# Altium schematic sheet Regulator.SchDoc
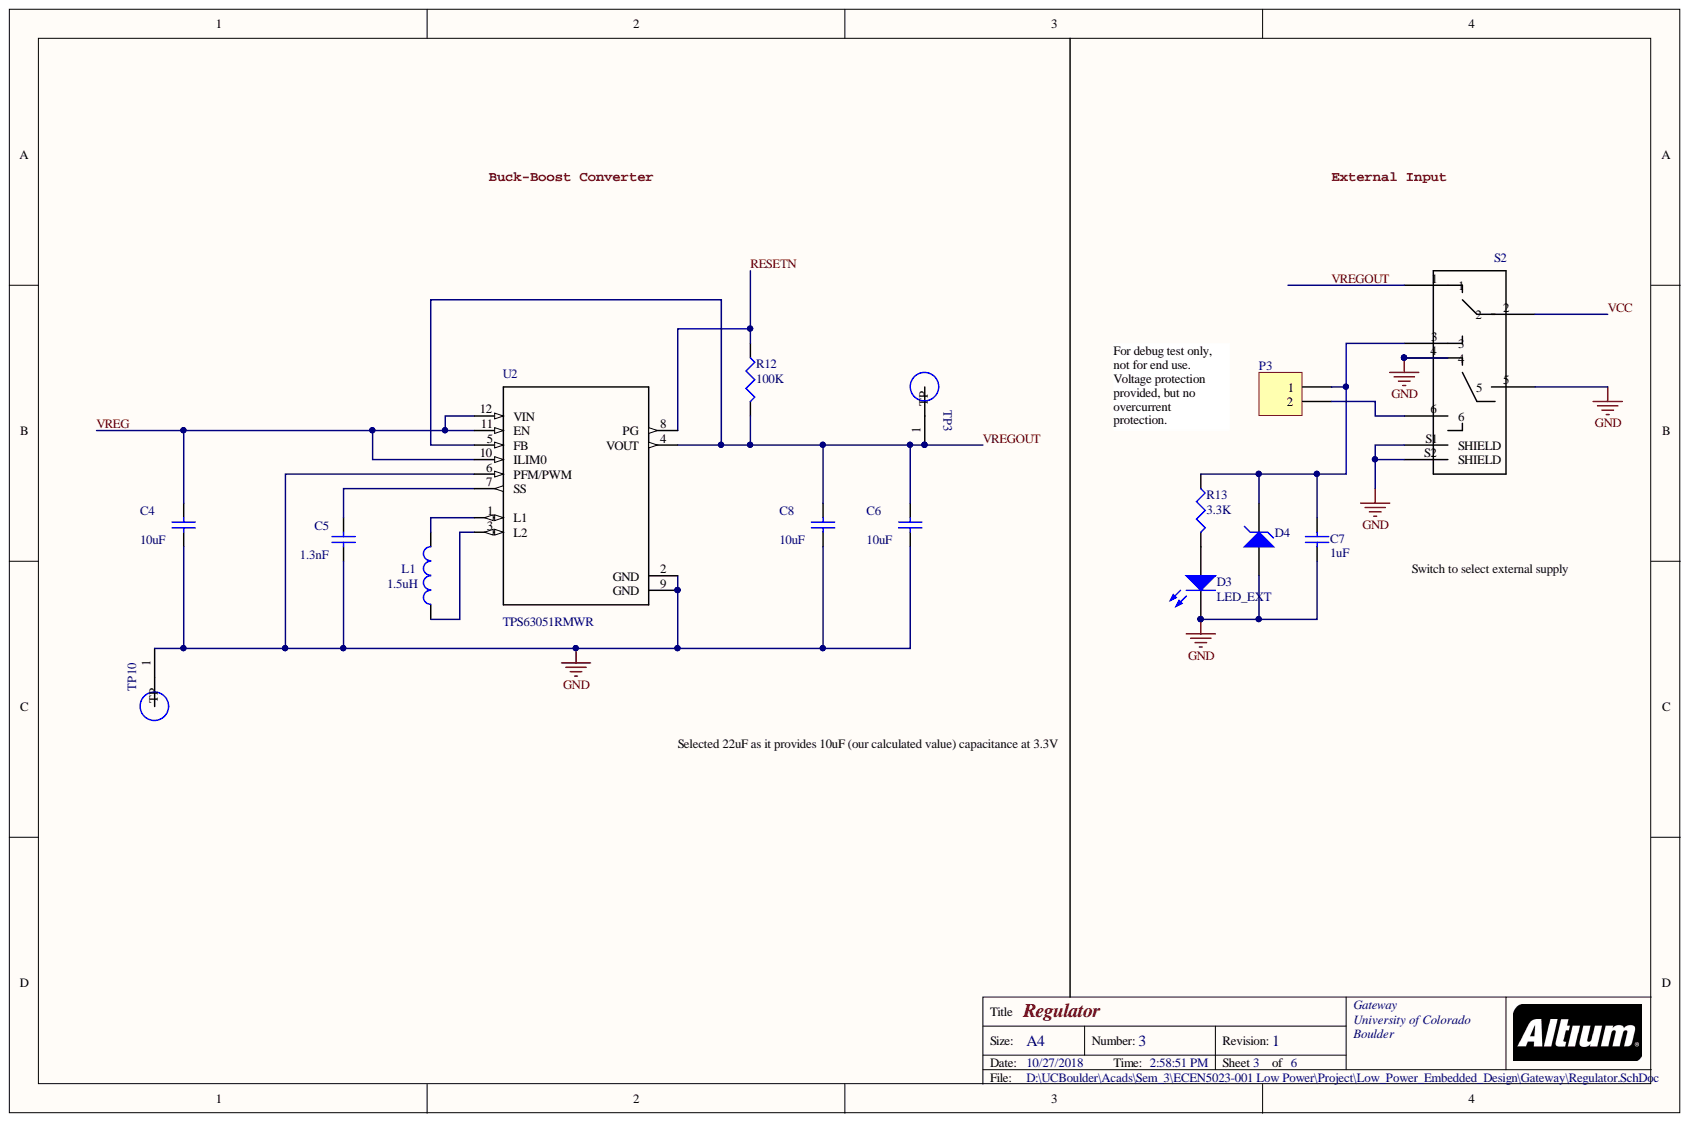
[diagram p0: Regulator.SchDoc]

## Parts
C4  value 10uF  [1608[0603]]  (hidden comment: GRM188R61A106KE69D)
C5  value 1.3nF  [1608[0603]]  (hidden comment: C0603C132J3GAC7867)
C6  value 10uF  [1608[0603]]  (hidden comment: GRM188R61A106KE69D)
C7  value 1uF  [1608[0603]]  (hidden comment: GCM188R71E104KA57D)
C8  value 10uF  [1608[0603]]  (hidden comment: GRM188R61A106KE69D)
D3  LED_EXT  [3.2X1.6X1.1]
D4  TPD1E10B06DPYR  [0402]  (value not drawn)
L1  value 1.5uH  [0603]  (hidden comment: LQM18PN1R5MFHD)
P3  Manufacturer Part Number  [HDR1X2]  (value not drawn)
R12  value 100K  [1608[0603]]  (hidden comment: RG1608P-104-B-T5)
R13  value 3.3K  [1608[0603]]  (hidden comment: ERJ-3GEYJ332V)
S2  1825289-1  [SW_1825289-1_1825289-1(Primary)]  (value not drawn)
TP3  5019  [TP380X203]  (value not drawn)
TP10  5019  [TP380X203]  (value not drawn)
U2  TPS63051RMWR  [QFN50P250X250X100-12N]

## Nets
GND: C4.1 C5.1 C6.1 C7.1 C8.1 D3.2 D4.1 S2.4 S2.5 S2.S1(SHIELD) S2.S2(SHIELD) TP10.1 U2.2(GND) U2.6(PFM/PWM) U2.9(GND)
RESETN: R12.1 U2.8(PG)
VCC: S2.2
VREG: C4.2 U2.10(ILIM0) U2.11(EN) U2.12(VIN)
VREGOUT: C6.2 C8.2 R12.2 S2.1 TP3.1 U2.4(VOUT) U2.5(FB)
NetC5_2: C5.2 U2.7(SS)
NetC7_2: C7.2 D4.2 P3.1 R13.2 S2.3
NetD3_1: D3.1 R13.1
NetL1_1: L1.1 U2.3(L2)
NetL1_2: L1.2 U2.1(L1)
NetP3_2: P3.2 S2.6

## Library data of the parts
C4 C6 C8 = Cap: CAP CER 10UF 10V X5R 0603; Manufacturer: Murata Electronics North America; Component Kind: Standard; ComponentLink1Description: Digi-Key Link; ComponentLink1URL: https://www.digikey.com/product-detail/en/murata-electronics-north-america/GRM188R61A106KE69D/490-10474-1-ND/5026392; Digi-Key Part Number: 490-10474-1-ND; LatestRevisionDate: 17-Jul-2002; LatestRevisionNote: Re-released for DXP Platform.; Library Name: Miscellaneous Devices.IntLib; Manufacturer Part Number: GRM188R61A106KE69D; PackageDescription: Radial Cap, Thru-Hole; 2 Leads; 0.3 in Pin Spacing; PackageReference: RAD-0.3; Published: 8-Jun-2000; Signal Integrity: Cap; Simulation: CAP; Publisher: Altium Limited
C5 = Cap: CAP CER 1300PF 25V C0G/NP0 0603; Manufacturer: KEMET; Component Kind: Standard; ComponentLink1Description: Digi-Key Link; ComponentLink1URL: https://www.digikey.com/product-detail/en/kemet/C0603C132J3GAC7867/399-14953-1-ND/7382502; Digi-Key Part Number: 399-14953-1-ND; LatestRevisionDate: 17-Jul-2002; LatestRevisionNote: Re-released for DXP Platform.; Library Name: Miscellaneous Devices.IntLib; Manufacturer Part Number: C0603C132J3GAC7867; PackageDescription: Radial Cap, Thru-Hole; 2 Leads; 0.3 in Pin Spacing; PackageReference: RAD-0.3; Published: 8-Jun-2000; Signal Integrity: Cap; Simulation: CAP; Publisher: Altium Limited
C7 = Cap Semi: CAP CER 0.1UF 25V X7R 0603; Manufacturer: Murata Electronics North America; Component Kind: Standard; ComponentLink1Description: Digi-Key Link; ComponentLink1URL: https://www.digikey.com/product-detail/en/murata-electronics-north-america/GCM188R71E104KA57D/490-6051-1-ND/3845251; Digi-Key Part Number: 490-6051-1-ND; Library Name: Miscellaneous Devices.IntLib; Manufacturer Part Number: GCM188R71E104KA57D; Signal Integrity: Cap Semi; Simulation: CAP
D3 = LED2: LED GREEN DIFFUSED 0603 SMD; Manufacturer: OSRAM Opto Semiconductors Inc.; Manufacturer Part Number: LG L29K-F2J1-24-Z; Component Kind: Standard; ComponentLink1Description: Digi-Key Link; ComponentLink1URL: https://www.digikey.com/product-detail/en/osram-opto-semiconductors-inc/LG-L29K-F2J1-24-Z/475-3118-1-ND/4899549; Digi-Key Part Number: 475-3118-1-ND; Library Name: Miscellaneous Devices.IntLib; Simulation: LED2
D4 = D Zener: Zener Diode; Manufacturer: Texas Instruments; Component Kind: Standard; ComponentLink1Description: Digi-Key Link; Digi-Key Part Number: 296-30406-1-ND; Library Name: Miscellaneous Devices.IntLib; Manufacturer Part Number: TPD1E10B06DPYR; Signal Integrity: D Zener; Simulation: ZENER
L1 = Inductor: FIXED IND 1.5UH 1.1A 213 MOHM; Manufacturer: Murata Electronics North America; Code_IEC: 1005; Code_JEITA: 0402; Component Kind: Standard; ComponentLink1Description: Digi-Key Link; ComponentLink1URL: https://www.digikey.com/product-detail/en/murata-electronics-north-america/LQM18PN1R5MFHD/490-15637-1-ND/6800302; Digi-Key Part Number: 490-15637-1-ND; LatestRevisionDate: 29-May-2009; LatestRevisionNote: IPC-7351 Footprint Added.; Library Name: Miscellaneous Devices.IntLib; Manufacturer Part Number: LQM18PN1R5MFHD; PackageDescription: Chip Inductor; PackageReference: 0402-A; Published: 8-Jun-2000; Signal Integrity: Inductor; Simulation: INDUCTOR; Publisher: Altium Limited
P3 = Header 2: Header, 2-Pin; Component Kind: Standard; LatestRevisionDate: 17-Jul-2002; LatestRevisionNote: Re-released for DXP Platform.; Library Name: Miscellaneous Connectors.IntLib; Signal Integrity: Connector; Publisher: Altium Limited
R12 = Res3: RES SMD 100K OHM 0.1% 1/10W 0603; Manufacturer: Susumu; Component Kind: Standard; ComponentLink1Description: Digi-Key Link; ComponentLink1URL: https://www.digikey.com/products/en/resistors/chip-resistor-surface-mount/52?k=RG1608P-104-B-T5&k=&pkeyword=RG1608P-104-B-T5&pv7=2&sf=0&quantity=&ColumnSort=0&page=1&pageSize=25; Digi-Key Part Number: RG16P100KBCT-ND; LatestRevisionDate: 29-May-2009; LatestRevisionNote: IPC-7351 Footprint Added.; Library Name: Miscellaneous Devices.IntLib; Manufacturer Part Number: RG1608P-104-B-T5; PackageDescription: Chip Resistor; PackageReference: J1-0603; Published: 8-Jun-2000; Signal Integrity: Res; Simulation: RESISTOR; Publisher: Altium Limited
R13 = Res3: RES SMD 3.3K OHM 5% 1/10W 0603; Manufacturer: Panasonic Electronic Components; Component Kind: Standard; ComponentLink1Description: Digi-Key URL; ComponentLink1URL: https://www.digikey.com/product-detail/en/panasonic-electronic-components/ERJ-3GEYJ332V/P3.3KGCT-ND/135146; Digi-Key Part Number: P3.3KGCT-ND; Library Name: Miscellaneous Devices.IntLib; Manufacturer Part Number: ERJ-3GEYJ332V; Signal Integrity: Res; Simulation: RESISTOR
S2 = 1825289-1: MHS22204=DPDT SL SW VERT AG; Manufacturer: TE Connectivity; Component Kind: Standard; ComponentLink1Description: Digi-Key Link; ComponentLink1URL: https://www.digikey.com/products/en?keywords=MHS22204; Digi-Key Part Number: 450-1572-ND; Library Name: 1825289-1.SchLib; Manufacturer Part Number: 1825289-1
TP3 TP10 = 5019: PC TEST POINT MINIATURE; Manufacturer: Keystone Electronics; MF: Keystone; Component Kind: Standard; ComponentLink1Description: Digi-Key Link; ComponentLink1URL: https://www.digikey.com/product-detail/en/keystone-electronics/5019/36-5019CT-ND/3907344?utm_source=snapeda&utm_medium=aggregator&utm_campaign=symbol; Digi-Key_Part_Number: 36-5019CT-ND; Digi-Key Part Number: 36-5019CT-ND; Library Name: 5019.IntLib; Manufacturer Part Number: 5019; MP: 5019; Package: 2010 Keystone; SnapEDA_Link: https://www.snapeda.com/parts/5019/Keystone%20Electronics/view-part/?ref=snap
U2 = TPS63051RMWR: Tiny Single Inductor Buck Boost Converter 12-VQFN -40 to 85; Manufacturer: Texas Instruments; Component Kind: Standard; ComponentLink1Description: Digi-Key Link; ComponentLink1URL: https://www.digikey.com/products/en/integrated-circuits-ics/pmic-voltage-regulators-dc-dc-switching-regulators/739?k=TPS63051RMWR&k=&pkeyword=TPS63051RMWR&pv7=2&sf=0&quantity=&ColumnSort=0&page=1&pageSize=25; Digi-Key Part Number: 296-42650-1-ND; Library Name: TPS63051RMWR.SchLib; Manufacturer Part Number: TPS63051RMWR
